# Altium schematic sheet Digital.SchDoc(Digital)
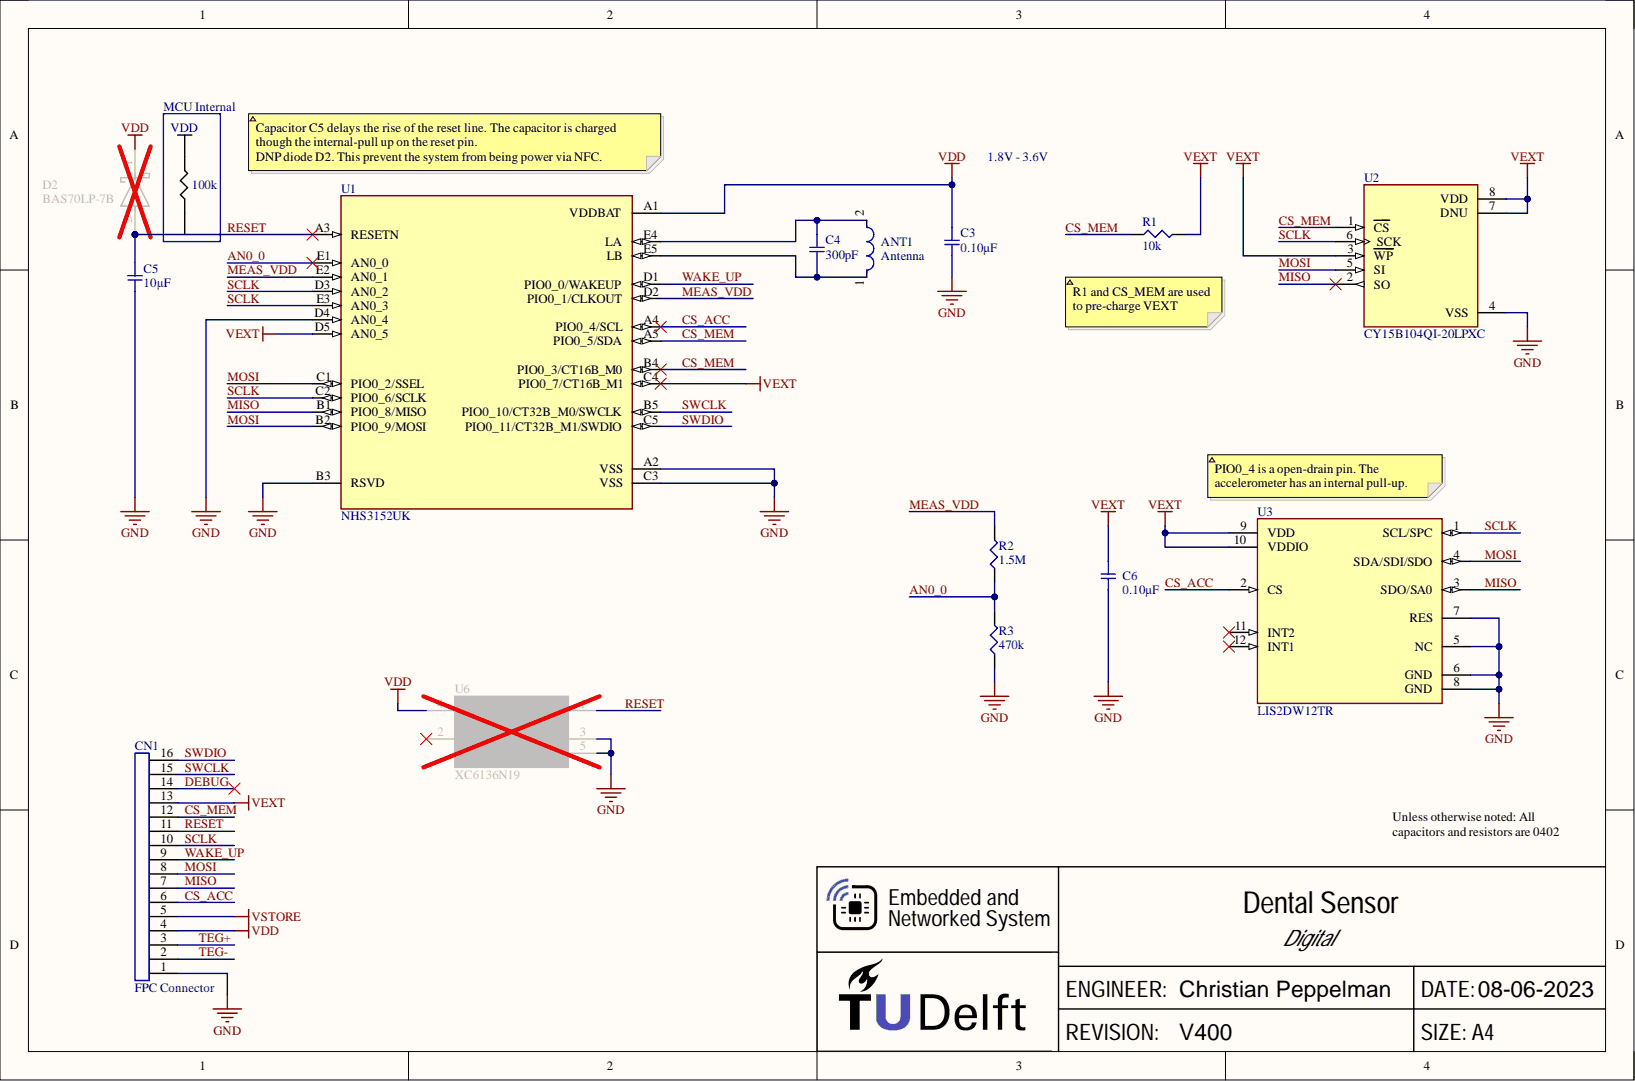
[diagram p0: Digital.SchDoc]

## Parts
ANT1  Antenna  [NFC Antenna]
C3  0.10µF  [RESC1005X03N]
C4  300pF  [RESC1005X03N]
C5  10µF  [RESC1005X03N]
C6  0.10µF  [RESC1005X03N]
CN1  FPC Connector  [52745-1697]
D2  BAS70LP-7B  [FP-X1-DFN1006-2-MFG]
R1  10k  [RESC1005X03N]
R2  1.5M  [RESC1005X03N]
R3  470k  [RESC1005X03N]
U1  NHS3152UK  [WLCSP25]
U2  CY15B104QI-20LPXC  [8-GQFN]
U3  LIS2DW12TR  [FP-LGA12-DM00170568-MFG]
U6  XC6136N19  [USPQ-4B05]

## Nets
GND: C3.2 C5.2 C6.2 CN1.1 R3.1 U1.A2(VSS) U1.B3(RSVD) U1.C3(VSS) U1.D4(AN0_4) U2.4(VSS) U3.5(NC) U3.6(GND) U3.7(RES) U3.8(GND) U6.3(VSS) U6.5(PAD)
VDD: C3.1 CN1.4 D2.1 U1.A1(VDDBAT) U6.4(VIN)
VEXT: C6.1 CN1.13 R1.2 U1.C4 U1.D5(AN0_5) U2.3(WP) U2.7(DNU) U2.8(VDD) U3.9(VDD) U3.10(VDDIO)
VSTORE: CN1.5
AN0_0: R2.1 R3.2 U1.E1(AN0_0)
CS_ACC: CN1.6 U1.A4 U3.2(CS)
CS_MEM: CN1.12 R1.1 U1.A5 U1.B4 U2.1(CS)
DEBUG: CN1.14
MEAS_VDD: R2.2 U1.D2 U1.E2(AN0_1)
MISO: CN1.7 U1.B1 U2.2(SO) U3.3(SDO/SA0)
MOSI: CN1.8 U1.B2 U1.C1 U2.5(SI) U3.4(SDA/SDI/SDO)
RESET: C5.1 CN1.11 D2.2 U1.A3(RESETN) U6.1(RESET)
SCLK: CN1.10 U1.C2 U1.D3(AN0_2) U1.E3(AN0_3) U2.6(SCK) U3.1(SCL/SPC)
SWCLK: CN1.15 U1.B5
SWDIO: CN1.16 U1.C5
TEG+: CN1.3
TEG-: CN1.2
WAKE_UP: CN1.9 U1.D1
NetANT1_1: ANT1.1 C4.2 U1.E5(LB)
NetANT1_2: ANT1.2 C4.1 U1.E4(LA)

Not connected: U3 11(INT2) 12(INT1); U6 2(NC)

## Library data of the parts
ANT1 = NFC Antenna: Track 0.2; Gap 0.1; Height 4.6; Width 14.5;; Component Kind: Net Tie; Library Name: Library.SchLib
C3 C6 = GRM155R60J104KA01D: CAP CER 0.1UF 6.3V X5R 0402; Manufacturer: Murata Electronics North America; @ qty: 0; Minimum Quantity: 1; Tolerance: ±10%; Unit Price (USD): 0.1; Applications: General Purpose; Component Kind: Standard; Datasheets: http://www.murata.com/~/media/webrenewal/support/library/catalog/products/capacitor/mlcc/c02e.pdf; Digi-Key Part Number: 490-6287-1-ND; Factory Stock: 0; Features: -; Height - Seated (Max): -; Image: //media.digikey.com/Renders/Murata%20Renders/ZRB-SERIES-0402.jpg; Lead Spacing: -; Library Name: capacitor_murata_0402.IntLib; Mounting Type: Surface Mount, MLCC; Operating Temperature: -55°C ~ 85°C; Package / Case: 0402 (1005 Metric); Packaging: Cut Tape (CT); Part Number: GRM155R60J104KA01D; Part Status: Active; Quantity Available: 356062; Ratings: -; Series: GRM; Size / Dimension: 0.039" L x 0.020" W (1.00mm x 0.50mm); Temperature Coefficient: X5R; Thickness (Max): 0.022" (0.55mm); Value: 0.10µF; Voltage: 6.3V
C4 = GRM1555C1H301JA01D: CAP CER 300PF 50V NP0 0402; Manufacturer: Murata Electronics North America; @ qty: 0; Minimum Quantity: 1; Temperature Coefficient: C0G, NP0; Tolerance: ±5%; Unit Price (USD): 0.1; Applications: General Purpose; Component Kind: Standard; Datasheets: http://www.murata.com/~/media/webrenewal/support/library/catalog/products/capacitor/mlcc/c02e.pdf; Digi-Key Part Number: 490-3234-1-ND; Factory Stock: 0; Features: -; Height - Seated (Max): -; Image: //media.digikey.com/Renders/Murata%20Renders/ZRB-SERIES-0402.jpg; Lead Spacing: -; Lead Style: -; Library Name: capacitor_murata_0402.IntLib; Mounting Type: Surface Mount, MLCC; Operating Temperature: -55°C ~ 125°C; Package / Case: 0402 (1005 Metric); Packaging: Cut Tape (CT); Part Number: GRM1555C1H301JA01D; Part Status: Active; Quantity Available: 73694; Ratings: -; Series: GRM; Size / Dimension: 0.039" L x 0.020" W (1.00mm x 0.50mm); Thickness (Max): 0.022" (0.55mm); Value: 300pF; Voltage: 50V
C5 = GRJ155R60J106ME11D: CAP CER 10UF 6.3V X5R 0402; Manufacturer: Murata Electronics North America; @ qty: 0; Minimum Quantity: 1; Tolerance: ±20%; Unit Price (USD): 0.23; Applications: Boardflex Sensitive; Component Kind: Standard; Datasheets: http://psearch.en.murata.com/capacitor/product/GRJ155R60J106ME11%23.pdf; Digi-Key Part Number: 490-13211-1-ND; Factory Stock: 0; Features: Derating Recommended, Soft Termination; Height - Seated (Max): -; Image: //media.digikey.com/Renders/Murata%20Renders/ZRB-SERIES-0402.jpg; Lead Spacing: -; Lead Style: -; Library Name: capacitor_murata_0402.IntLib; Mounting Type: Surface Mount, MLCC; Operating Temperature: -55°C ~ 85°C; Package / Case: 0402 (1005 Metric); Packaging: Cut Tape (CT); Part Number: GRJ155R60J106ME11D; Part Status: Active; Quantity Available: 64064; Ratings: -; Series: GRJ; Size / Dimension: 0.039" L x 0.020" W (1.00mm x 0.50mm); Temperature Coefficient: X5R; Thickness (Max): 0.028" (0.70mm); Value: 10µF; Voltage: 6.3V
CN1 = 52745-1697: FFC / FPC Board Connector, 0.5 mm, 16 Contacts, Receptacle, Easy-On 52745, Surface Mount, Top; Component Kind: Standard; Library Name: Library.SchLib
D2 = CMP-12790-000002-1: DIODE SCHTTKY 70V 70MA X1DFN1006; Manufacturer: Diodes Inc.; Capacitance: 1pF; Max Junction Temp: +150°C; Max Surge Forward Current: 800mA; Peak Reverse Current: 0.1uA; Standoff Height: 0mm; Value: 1pF; Automotive: No; Category: Diode; Component Kind: Standard; ComponentLink2Description: Datasheet; ComponentLink2URL: https://www.diodes.com/assets/Datasheets/BAS70LP.pdf; Device Class L1: Discrete Semiconductors; Device Class L2: Diodes; Device Class L3: Schottky Diodes; Digikey Description: DIODE SCHTTKY 70V 70MA X1DFN1006; Footprint Url: https://www.diodes.com/assets/Package-Files/X1-DFN1006-2.pdf; Forward Current: 70mA; Height: 0.53mm; Lead Free: Yes; Library Name: Altium Content Vault; Manufacturer Part Number: BAS70LP-7B; Max Repetitive Reverse Voltage: 70V; Mouser Description: Schottky Diodes & Rectifiers Schottky Diode; Octopart: https://octopart.com/bas70lp-7b-diodes+inc.-22053921; Package: X1-DFN1006-2; Reverse Voltage: 70V; RoHS: TRUE; Temperature: -65°C to +150°C; Temperature Range High: +150°C; Temperature Range Low: -65°C
R1 = ERJ-2GEJ103X: RES SMD 10K OHM 5% 1/10W 0402; Manufacturer: Panasonic Electronic Components; @ qty: 1500; Factory Stock: 0; Minimum Quantity: 1; Power (Watts): 0.1W, 1/10W; Temperature Coefficient: ±200ppm/°C; Unit Price (USD): 0.00350; Component Kind: Standard; Composition: Thick Film; Datasheets: http://industrial.panasonic.com/www-cgi/jvcr13pz.cgi?E+PZ+3+AOA0001+ERJ2GEJ103X+7+WW; Digi-Key Part Number: P10KJCT-ND; Failure Rate: -; Features: Automotive AEC-Q200; Height - Seated (Max): 0.016" (0.40mm); Image: //media.digikey.com/Photos/Panasonic%20Photos/ERJ-PA2-Series.jpg; Library Name: Panasonic_ERJ_0402.IntLib; Number of Terminations: 2; Operating Temperature: -55°C ~ 155°C; Package / Case: 0402 (1005 Metric); Packaging: Cut Tape (CT); Part Number: ERJ-2GEJ103X; Part Status: Active; Quantity Available: 2560215; Series: ERJ; Size / Dimension: 0.039" L x 0.020" W (1.00mm x 0.50mm); Supplier Device Package: 0402; Tolerance: ±5%; Value: 10k
R2 = ERJ-2GEJ155X: RES SMD 1.5M OHM 5% 1/10W 0402; Manufacturer: Panasonic Electronic Components; @ qty: 1500; Factory Stock: 0; Minimum Quantity: 1; Power (Watts): 0.1W, 1/10W; Temperature Coefficient: -400/ +150ppm/°C; Unit Price (USD): 0.00350; Component Kind: Standard; Composition: Thick Film; Datasheets: http://industrial.panasonic.com/www-cgi/jvcr13pz.cgi?E+PZ+3+AOA0001+ERJ2GEJ155X+7+WW; Digi-Key Part Number: P1.5MJCT-ND; Failure Rate: -; Features: Automotive AEC-Q200; Height - Seated (Max): 0.016" (0.40mm); Image: //media.digikey.com/Photos/Panasonic%20Photos/ERJ-PA2-Series.jpg; Library Name: Panasonic_ERJ_0402.IntLib; Number of Terminations: 2; Operating Temperature: -55°C ~ 155°C; Package / Case: 0402 (1005 Metric); Packaging: Cut Tape (CT); Part Number: ERJ-2GEJ155X; Part Status: Active; Quantity Available: 39239; Series: ERJ; Size / Dimension: 0.039" L x 0.020" W (1.00mm x 0.50mm); Supplier Device Package: 0402; Tolerance: ±5%; Value: 1.5M
R3 = ERJ-2RKF4703X: RES SMD 470K OHM 1% 1/10W 0402; Manufacturer: Panasonic Electronic Components; @ qty: 1500; Factory Stock: 0; Minimum Quantity: 1; Power (Watts): 0.1W, 1/10W; Temperature Coefficient: ±100ppm/°C; Unit Price (USD): 0.00496; Component Kind: Standard; Composition: Thick Film; Datasheets: http://industrial.panasonic.com/www-cgi/jvcr13pz.cgi?E+PZ+3+AOA0002+ERJ2RKF4703X+7+WW; Digi-Key Part Number: P470KLCT-ND; Failure Rate: -; Features: Automotive AEC-Q200; Height - Seated (Max): 0.016" (0.40mm); Image: //media.digikey.com/Photos/Panasonic%20Photos/ERJ-PA2-Series.jpg; Library Name: Panasonic_ERJ_0402.IntLib; Number of Terminations: 2; Operating Temperature: -55°C ~ 155°C; Package / Case: 0402 (1005 Metric); Packaging: Cut Tape (CT); Part Number: ERJ-2RKF4703X; Part Status: Active; Quantity Available: 171557; Series: ERJ; Size / Dimension: 0.039" L x 0.020" W (1.00mm x 0.50mm); Supplier Device Package: 0402; Tolerance: ±1%; Value: 470k
U1 = NHS3152UK: ARM-microcontrollers - MCU NTAG NHS3152 NFC; Component Kind: Standard; Library Name: Library.SchLib
U2 = CY15B104QI-20LPXC: FRAM (Ferroelectric RAM) Memory IC 4Mbit SPI 20 MHz 8-GQFN (3.23x3.28); Component Kind: Standard; Library Name: Library.SchLib
U3 = CMP-12175-000006-1: ACCEL 2-16G I2C/SPI 12LGA; Manufacturer: STMicroelectronics; Accel Range: 2/4/8/16g; Accel Resolution: 14bits; Max Supply Voltage: 3.6V; Min Supply Voltage: 1.62V; Operating Supply Current: 0.38-90uA; Sensor Output: I2C,SPI; Automotive: No; Category: IC; Component Kind: Standard; ComponentLink2Description: Datasheet; ComponentLink2URL: https://www.st.com/resource/en/datasheet/lis2dw12.pdf; Device Class L1: Sensors; Device Class L2: Motion Sensors; Device Class L3: Accelerometers; Digikey Description: ACCEL 2-16G I2C/SPI 12LGA; Footprint Url: https://www.st.com/content/ccc/resource/technical/document/technical_note/73/17/9c/16/dd/96/4a/01/CD00134799.pdf/files/CD00134799.pdf/jcr:content/translations/en.CD00134799.pdf; Height: 0.7mm; Lead Free: Yes; Library Name: Altium Content Vault; Manufacturer Part Number: LIS2DW12TR; Mouser Description: Accelerometer Triple ±2g/±4g/±8g/±16g 1.62V to 3.6V 0.244mg/digit/0.488mg/digit/0.976mg/digit/1.952mg/digit 12-Pin LG...; Octopart: https://octopart.com/lis2dw12tr-stmicroelectronics-77080925; Package: LGA12-DM00170568; RoHS: TRUE; Temperature: -40°C to +85°C; Temperature Range High: +85°C; Temperature Range Low: -40°C
U6 = XC6136C19ANR-G: Controlecircuits Ultra-Low Power (88nA) Voltage Detector; Component Kind: Standard; Library Name: Library.SchLib
